# Altium schematic sheet Sheet_TPS5430.SchDoc
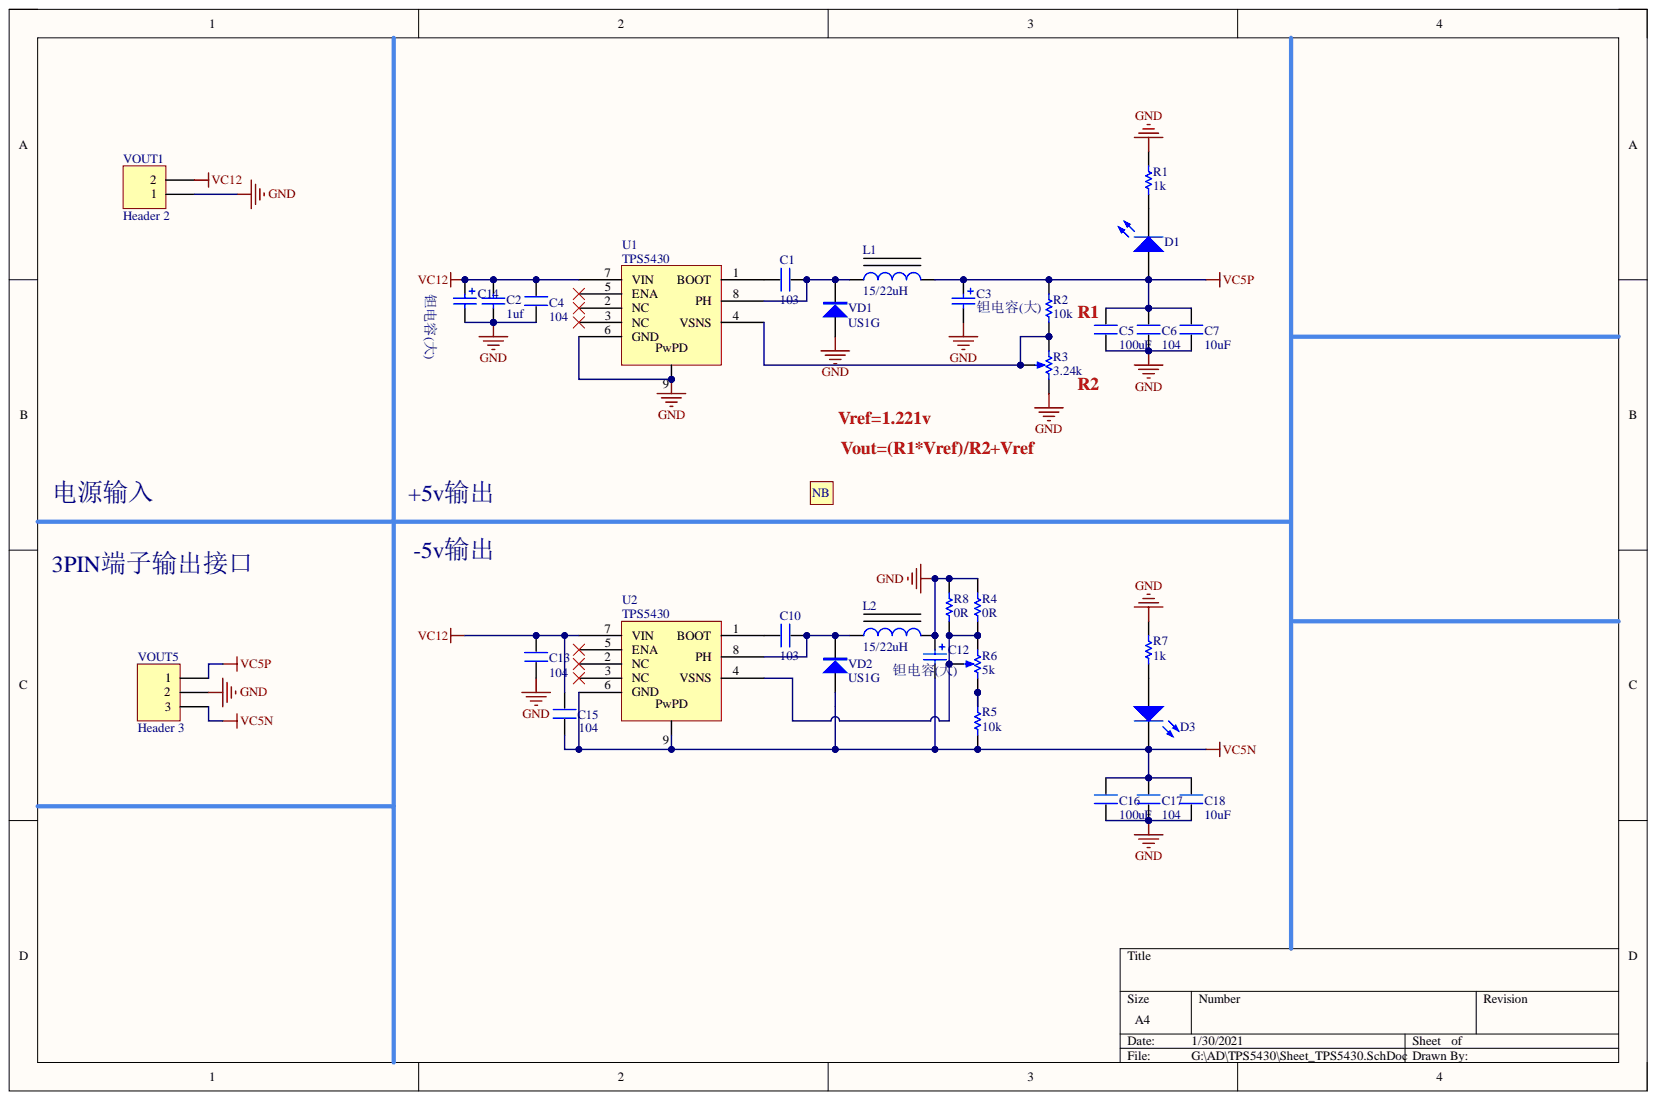
[diagram p0: Sheet_TPS5430.SchDoc]

## Parts
C1  103  [0805c]
C2  1uf  [1812]
C3  钽电容(大)  [CD A(3216)]  (value not drawn)
C4  104  [0805c]
C5  100uF  [1206C]
C6  104  [0805c]
C7  10uF  [0805c]
C10  103  [0805c]
C12  钽电容(大)  [CD A(3216)]
C13  104  [0805c]
C14  钽电容(大)  [CD A(3216)]  (value not drawn)
C15  104  [0805c]
C16  100uF  [1206C]
C17  104  [0805c]
C18  10uF  [0805c]
D1  0805led  [LED 0805B]  (value not drawn)
D3  0805led  [LED 0805B]  (value not drawn)
L1  15/22uH  [CD42]
L2  15/22uH  [CD42]
picture1  图标  [rapter]  (value not drawn)
R1  1k  [R 0603]
R2  10k  [R 0603]
R3  3.24k  [RPart]
R4  0R  [R 0603]
R5  10k  [R 0603]
R6  5k  [RPart]
R7  1k  [R 0603]
R8  0R  [R 0603]
U1  TPS5430  [SOIC-8(add 9pin)]
U2  TPS5430  [SOIC-8(add 9pin)]
VD1  US1G  [SMA]
VD2  US1G  [SMA]
VOUT1  Header 2  [HDR-1X2]
VOUT5  Header 3  [HDR-1X3]

## Nets
GND: C2.2 C3.2 C4.1 C5.1 C6.1 C7.1 C12.1 C13.1 C14.2 C16.1 C17.1 C18.1 L2.2 R1.2 R3.1 R4.2 R7.1 R8.2 U1.6(GND) U1.9 VD1.1 VOUT1.1 VOUT5.2
VC5N: C12.2 C15.1 C16.2 C17.2 C18.2 D3.2 R5.1 U2.6(GND) U2.9 VD2.1 VOUT5.3
VC5P: C3.1 C5.2 C6.2 C7.2 D1.1 L1.2 R2.2 VOUT5.1
VC12: C2.1 C4.2 C13.2 C14.1 C15.2 U1.7(VIN) U2.7(VIN) VOUT1.2
NetC1_1: C1.1 U1.1(BOOT)
NetC1_2: C1.2 L1.1 U1.8(PH) VD1.2
NetC10_1: C10.1 U2.1(BOOT)
NetC10_2: C10.2 L2.1 U2.8(PH) VD2.2
NetD1_2: D1.2 R1.1
NetD3_1: D3.1 R7.2
NetR2_1: R2.1 R3.2 R3.3 U1.4(VSNS)
NetR4_1: R4.1 R6.2 R6.3 R8.1 U2.4(VSNS)
NetR5_2: R5.2 R6.1

Not connected: U1 2(NC) 3(NC) 5(ENA); U2 2(NC) 3(NC) 5(ENA)

## Library data of the parts
C1 C10 = 0805C: Component Kind: Standard; Library Name: Mlia.SchLib
C2 = Cap: 贴片电容; Component Kind: Standard; LatestRevisionDate: 17-Jul-2002; LatestRevisionNote: Re-released for DXP Platform.; Library Name: Miscellaneous Devices.IntLib; PackageDescription: Radial Cap, Thru-Hole; 2 Leads; 0.3 in Pin Spacing; PackageReference: RAD-0.3; Published: 8-Jun-2000; Signal Integrity: Cap; Simulation: CAP; Publisher: Altium Limited
C4 C6 C7 C13 C15 C17 C18 = 0805c: Component Kind: Standard; Library Name: Mlia.SchLib
C5 C16 = 1206c: Component Kind: Standard; Library Name: Mlia.SchLib
L1 L2 = 电感: Component Kind: Standard; Library Name: Mlia.SchLib
picture1 = SP: Component Kind: Standard; Library Name: LONG_lclr.SchLib
R1 R2 R4 R5 R7 R8 = 0805r: Component Kind: Standard; Library Name: Mlia.SchLib
R3 R6 = RES_TAP: Component Kind: Standard; Library Name: Mlia.SchLib
VD1 VD2 = SS14: 肖特基二极管; 属性: 40V/1A; 版权归属: 源创客; BOM名称: 肖特基二极管; Component Kind: Standard; Library Name: 3D Mode.IntLib; 学习资源: www.ooocke.com
VOUT1 = Header 2: Header, 2-Pin; Component Kind: Standard; LatestRevisionDate: 17-Jul-2002; LatestRevisionNote: Re-released for DXP Platform.; Library Name: Miscellaneous Connectors.IntLib; Signal Integrity: Connector; Publisher: Altium Limited
VOUT5 = Header 3: Header, 3-Pin; Component Kind: Standard; LatestRevisionDate: 17-Jul-2002; LatestRevisionNote: Re-released for DXP Platform.; Library Name: Miscellaneous Connectors.IntLib; Signal Integrity: Connector; Publisher: Altium Limited
C3 C12 C14 = 钽电容(大): Component Kind: Standard; Library Name: Mlia.SchLib
D1 D3 = 0805led: Component Kind: Standard; Library Name: Mlia.SchLib
U1 U2 = TPS5430: Component Kind: Standard; Library Name: Mlia.SchLib
